# Altium schematic sheet 8-Controller.SchDoc(8-Controller)
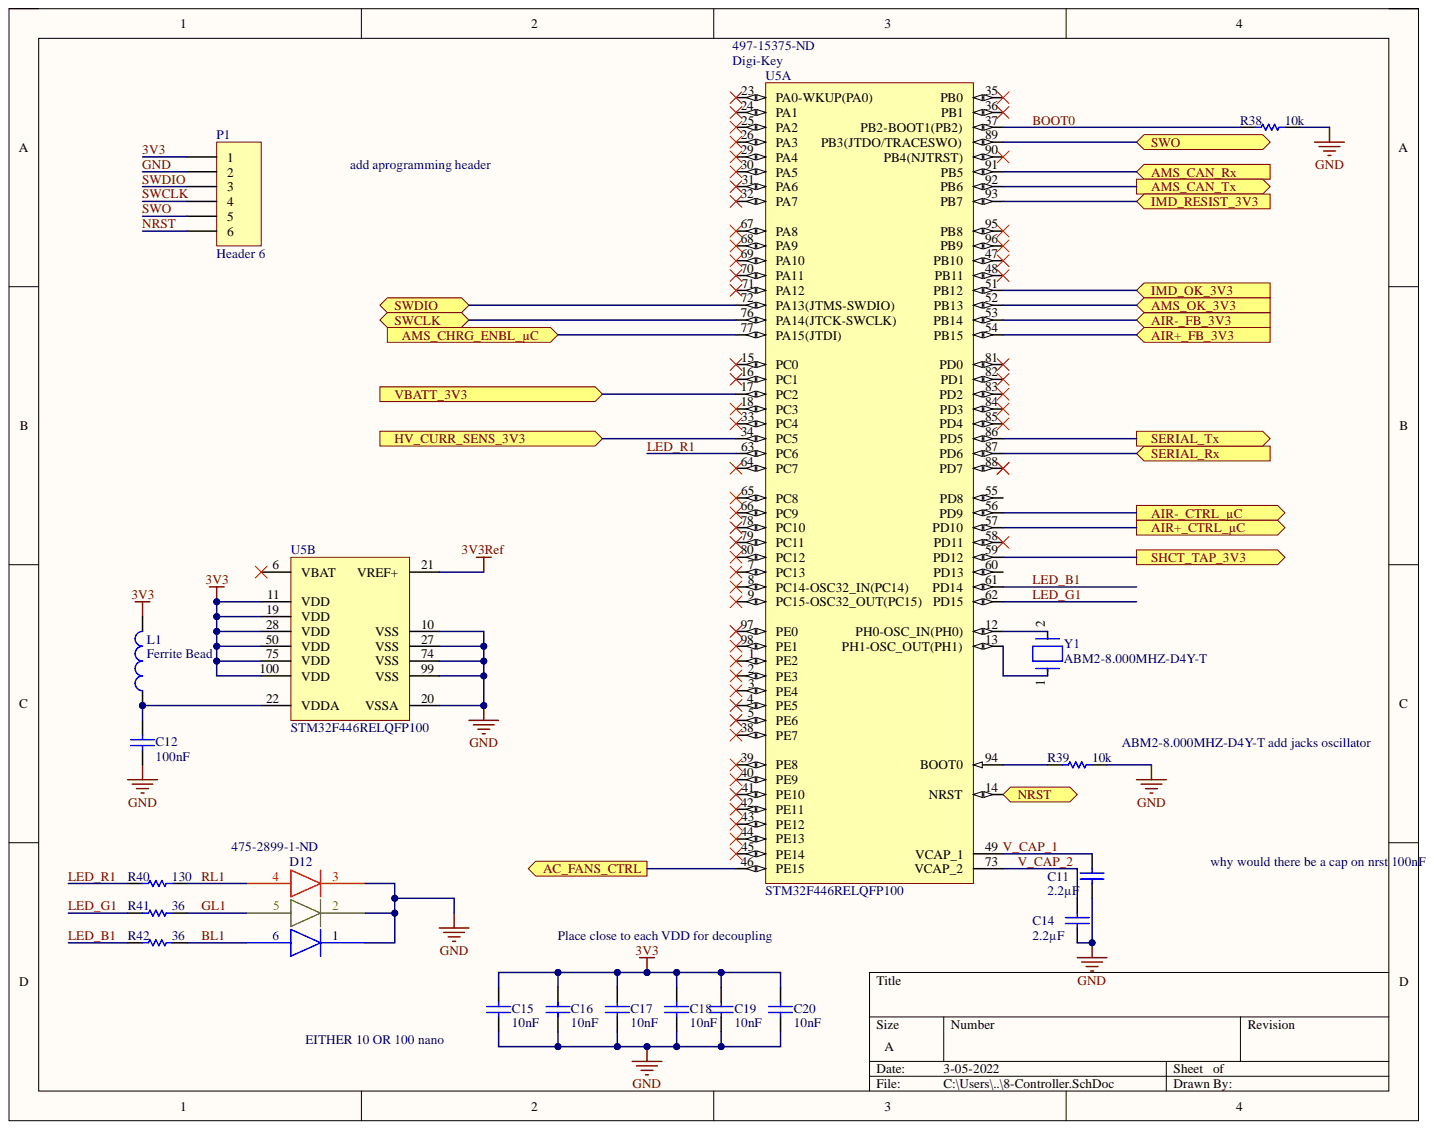
[diagram p0: 8-Controller.SchDoc]

## Parts
C11  Capacitance: 2.2µF  [1608[0603]]  (hidden comment: Cap)
C12  value 100nF  [1608[0603]]  (hidden comment: Cap)
C14  Capacitance: 2.2µF  [1608[0603]]  (hidden comment: Cap)
C15  value 10nF  [1608[0603]]  (hidden comment: Cap)
C16  value 10nF  [1608[0603]]  (hidden comment: Cap)
C17  value 10nF  [1608[0603]]  (hidden comment: Cap)
C18  value 10nF  [1608[0603]]  (hidden comment: Cap)
C19  value 10nF  [1608[0603]]  (hidden comment: Cap)
C20  value 10nF  [1608[0603]]  (hidden comment: Cap)
D12  Supplier Part Number 1: 475-2899-1-ND  [CLX6C-FKB]
L1  Ferrite Bead  [1608[0603]]
P1  Header 6  [HDR1X6]
R38  value 10k  Resistance (Ohms): 10k  [1608[0603]]  (hidden comment: Res1)
R39  value 10k  Resistance (Ohms): 10k  [1608[0603]]  (hidden comment: Res1)
R40  value 130  [1608[0603]]  (hidden comment: Res1)
R41  value 36  [1608[0603]]  (hidden comment: Res1)
R42  value 36  [1608[0603]]  (hidden comment: Res1)
U5  STM32F446RELQFP100  Supplier 1: Digi-Key  [STM-LQFP100_M]  (parts A,B)
Y1  ABM2-8.000MHZ-D4Y-T  [FP-ABM2-MFG]

## Ports
AC_FANS_CTRL, AIR+_CTRL_µC, AIR+_FB_3V3, AIR-_CTRL_µC, AIR-_FB_3V3, AMS_CAN_Rx, AMS_CAN_Tx, AMS_CHRG_ENBL_µC, AMS_OK_3V3, HV_CURR_SENS_3V3, IMD_OK_3V3, IMD_RESIST_3V3, NRST, SERIAL_Rx, SERIAL_Tx, SHCT_TAP_3V3, SWCLK, SWDIO, SWO, VBATT_3V3

## Nets
3V3Ref: U5.21(VREF+)
3V3: C15.2 C16.2 C17.2 C18.2 C19.2 C20.2 L1.2 P1.1 U5.11(VDD) U5.19(VDD) U5.28(VDD) U5.50(VDD) U5.75(VDD) U5.100(VDD)
AC_FANS_CTRL: U5.46(PE15) ; port AC_FANS_CTRL
AMS_CAN_Rx: U5.91(PB5) ; port AMS_CAN_Rx
AMS_CAN_Tx: U5.92(PB6) ; port AMS_CAN_Tx
AMS_CHRG_ENBL_µC: U5.77(PA15(JTDI)) ; port AMS_CHRG_ENBL_µC
AMS_OK_3V3: U5.52(PB13) ; port AMS_OK_3V3
BL1: D12.6 R42.2
BOOT0: R38.1 U5.37(PB2-BOOT1(PB2))
GL1: D12.5 R41.2
GND: C11.1 C12.1 C14.1 C15.1 C16.1 C17.1 C18.1 C19.1 C20.1 D12.1 D12.2 D12.3 P1.2 R38.2 R39.2 U5.10(VSS) U5.20(VSSA) U5.27(VSS) U5.74(VSS) U5.99(VSS)
HV_CURR_SENS_3V3: U5.34(PC5) ; port HV_CURR_SENS_3V3
IMD_OK_3V3: U5.51(PB12) ; port IMD_OK_3V3
IMD_RESIST_3V3: U5.93(PB7) ; port IMD_RESIST_3V3
LED_B1: R42.1 U5.61(PD14)
LED_G1: R41.1 U5.62(PD15)
LED_R1: R40.1 U5.63(PC6)
NRST: P1.6
RL1: D12.4 R40.2
SERIAL_Rx: U5.87(PD6) ; port SERIAL_Rx
SERIAL_Tx: U5.86(PD5) ; port SERIAL_Tx
SHCT_TAP_3V3: U5.59(PD12) ; port SHCT_TAP_3V3
SWCLK: P1.4
SWDIO: P1.3
SWO: P1.5
V_CAP_1: C11.2 U5.49(VCAP_1)
V_CAP_2: C14.2 U5.73(VCAP_2)
VBATT_3V3: U5.17(PC2) ; port VBATT_3V3
NetC12_2: C12.2 L1.1 U5.22(VDDA)
NetR39_1: R39.1 U5.94(BOOT0)
NetU5_12: U5.12(PH0-OSC_IN(PH0)) Y1.2
NetU5_13: U5.13(PH1-OSC_OUT(PH1)) Y1.1
NetU5_14: U5.14(NRST) ; port NRST
NetU5_53: U5.53(PB14)
NetU5_54: U5.54(PB15)
NetU5_56: U5.56(PD9)
NetU5_57: U5.57(PD10)
NetU5_72: U5.72(PA13(JTMS-SWDIO)) ; port SWDIO
NetU5_76: U5.76(PA14(JTCK-SWCLK)) ; port SWCLK
NetU5_89: U5.89(PB3(JTDO/TRACESWO)) ; port SWO

Not connected: U5 1(PE2) 2(PE3) 3(PE4) 4(PE5) 5(PE6) 6(VBAT) 7(PC13) 8(PC14-OSC32_IN(PC14)) 9(PC15-OSC32_OUT(PC15)) 15(PC0) 16(PC1) 18(PC3) 23(PA0-WKUP(PA0)) 24(PA1) 25(PA2) 26(PA3) 29(PA4) 30(PA5) 31(PA6) 32(PA7) 33(PC4) 35(PB0) 36(PB1) 38(PE7) 39(PE8) 40(PE9) 41(PE10) 42(PE11) 43(PE12) 44(PE13) 45(PE14) 47(PB10) 48(PB11) 55(PD8) 58(PD11) 60(PD13) 64(PC7) 65(PC8) 66(PC9) 67(PA8) 68(PA9) 69(PA10) 70(PA11) 71(PA12) 78(PC10) 79(PC11) 80(PC12) 81(PD0) 82(PD1) 83(PD2) 84(PD3) 85(PD4) 88(PD7) 90(PB4(NJTRST)) 95(PB8) 96(PB9) 97(PE0) 98(PE1)

## Library data of the parts
C11 C14 = Cap: Capacitor; Manufacturer: Taiyo Yuden; Manufacturer Part Number: TMK107BBJ225KAHT; Temperature Coefficient: X5R; Tolerance: ±10%; Voltage - Rated: 25V; Applications: General Purpose; Category: Capacitors; Component Kind: Standard; ComponentLink1Description: http://www.yuden.co.jp/productdata/catalog/en/mlcc_all_hq_e.pdf; ComponentLink1URL: http://www.yuden.co.jp/productdata/catalog/en/mlcc_all_hq_e.pdf; Features: -; Height - Seated (Max): -; Lead Spacing: -; Lead Style: -; Library Name: Miscellaneous Devices.IntLib; Mounting Type: Surface Mount, MLCC; Operating Temperature: -55°C ~ 85°C; Package / Case: 0603 (1608 Metric); Packaging: Cut Tape (CT); Part Status: Active; Pricing 1: 1=0.28, 10=0.193, 25=0.1416, 50=0.116, 100=0.0979, 250=0.07992, 500=0.06702, 1000=0.05928 (CAD); Ratings: AEC-Q200; RoHS: RoHS Compliant; Signal Integrity: Cap; Simulation: CAP; Size / Dimension: 0.063" L x 0.031" W (1.60mm x 0.80mm); Stock 1: 6587; Supplier 1: Digi-Key; Supplier Part Number 1: 587-4333-1-ND; Thickness (Max): 0.035" (0.90mm)
C12 = Cap: Capacitor; Manufacturer: Yageo; Manufacturer Part Number: CC0603KRX7R9BB104; Capacitance: 0.10µF; Temperature Coefficient: X7R; Tolerance: ±10%; Voltage - Rated: 50V; Applications: General Purpose; Category: Capacitors; Component Kind: Standard; ComponentLink1Description: http://www.yageo.com/documents/recent/UPY-GPHC_X7R_6.3V-to-50V_16.pdf; ComponentLink1URL: http://www.yageo.com/documents/recent/UPY-GPHC_X7R_6.3V-to-50V_16.pdf; Failure Rate: -; Features: -; Height - Seated (Max): -; Lead Spacing: -; Lead Style: -; Library Name: Miscellaneous Devices.IntLib; Mounting Type: Surface Mount, MLCC; Operating Temperature: -55°C ~ 125°C; Package / Case: 0603 (1608 Metric); Packaging: Cut Tape (CT); Part Status: Active; Pricing 1: 1=0.15, 10=0.043, 25=0.0312, 50=0.0232, 100=0.0189, 250=0.0158, 500=0.01346, 1000=0.01059 (CAD); Ratings: -; RoHS: RoHS Compliant; Signal Integrity: Cap; Simulation: CAP; Size / Dimension: 0.063" L x 0.031" W (1.60mm x 0.80mm); Stock 1: 4016262; Supplier 1: Digi-Key; Supplier Part Number 1: 311-1344-1-ND; Thickness (Max): 0.035" (0.90mm)
C15-C20 = Cap: Capacitor; Manufacturer: Yageo; Manufacturer Part Number: CC0603KRX7R9BB103; Capacitance: 10000pF; Temperature Coefficient: X7R; Tolerance: ±10%; Voltage - Rated: 50V; Applications: General Purpose; Category: Capacitors; Component Kind: Standard; ComponentLink1Description: http://www.yageo.com/documents/recent/UPY-GPHC_X7R_6.3V-to-50V_16.pdf; ComponentLink1URL: http://www.yageo.com/documents/recent/UPY-GPHC_X7R_6.3V-to-50V_16.pdf; Failure Rate: -; Features: -; Height - Seated (Max): -; Lead Spacing: -; Lead Style: -; Library Name: Miscellaneous Devices.IntLib; Mounting Type: Surface Mount, MLCC; Operating Temperature: -55°C ~ 125°C; Package / Case: 0603 (1608 Metric); Packaging: Cut Tape (CT); Part Status: Active; Pricing 1: 1=0.15, 10=0.026, 25=0.0192, 50=0.0144, 100=0.0117, 250=0.0098, 500=0.00836, 1000=0.00657 (CAD); Ratings: -; RoHS: RoHS Compliant; Signal Integrity: Cap; Simulation: CAP; Size / Dimension: 0.063" L x 0.031" W (1.60mm x 0.80mm); Stock 1: 3577285; Supplier 1: Digi-Key; Supplier Part Number 1: 311-1085-1-ND; Thickness (Max): 0.035" (0.90mm)
D12 = LED - CLX6C-FKB-CGJKNCFBB789363 RGB: Manufacturer: Cree Inc.; Color: Red, Green, Blue (RGB); Current - Test: 8mA Red, 8mA Green, 8mA Blue; Lens Color: White; Lens Style/Size: Square with Flat Top, 1.00mm; Lens Transparency: Diffused; Millicandela Rating: 420mcd Red, 840mcd Green, 196mcd Blue; Voltage - Forward (Vf) (Typ): 1.9V Red, 3V Green, 3V Blue; Wavelength - Dominant: 622nm Red, 531nm Green, 470nm Blue; Category: Optoelectronics; Component Kind: Standard; ComponentLink1Description: http://www.cree.com/~/media/Files/Cree/LED-Components-and-Modules/HB/Data-Sheets/1281-CLX6C-FKB.pdf; ComponentLink1URL: http://www.cree.com/~/media/Files/Cree/LED-Components-and-Modules/HB/Data-Sheets/1281-CLX6C-FKB.pdf; Features: -; Height (Max): 2.90mm; Manufacturer Part Number: CLX6C-FKB-CGJKNCFBB789363; Mounting Type: Surface Mount; Package / Case: 6-SMD, J-Lead; Packaging: Cut Tape (CT); Part Status: Active; RoHS: RoHS Compliant; Size / Dimension: 3.40mm L x 3.40mm W; Supplier 1: Digi-Key; Supplier Device Package: 6-PLCC; Viewing Angle: -; Wavelength - Peak: -; Library Name: VCU Library.SchLib
L1 = Inductor: Code_IEC: 1005; Code_JEITA: 0402; Component Kind: Standard; Description: Inductor; LatestRevisionDate: 29-May-2009; LatestRevisionNote: IPC-7351 Footprint Added.; Library Name: Miscellaneous Devices.IntLib; PackageDescription: Chip Inductor; PackageReference: 0402-A; Published: 8-Jun-2000; Signal Integrity: Inductor; Simulation: INDUCTOR; Supplier 1: Digi-Key; Supplier Part Number 1: 240-2369-1-ND; Publisher: Altium Limited
P1 = Header 6: Header, 6-Pin; Component Kind: Standard; LatestRevisionDate: 17-Jul-2002; LatestRevisionNote: Re-released for DXP Platform.; Library Name: Miscellaneous Connectors.IntLib; Signal Integrity: Connector; Publisher: Altium Limited
R38 R39 = Res1: Resistor; Manufacturer: Yageo; Manufacturer Part Number: RC0603JR-0710KL; Power (Watts): 0.1W, 1/10W; Temperature Coefficient: ±100ppm/°C; Tolerance: ±5%; Category: Resistors; Component Kind: Standard; ComponentLink1Description: http://www.yageo.com/NewPortal/yageodocoutput?fileName=/pdf/R-Chip/PYu-RC_Group_51_RoHS_L_4.pdf; ComponentLink1URL: http://www.yageo.com/NewPortal/yageodocoutput?fileName=/pdf/R-Chip/PYu-RC_Group_51_RoHS_L_4.pdf; Composition: Thick Film; Failure Rate: -; Features: Moisture Resistant; Height - Seated (Max): 0.022" (0.55mm); Library Name: Miscellaneous Devices.IntLib; Number of Terminations: 2; Operating Temperature: -55°C ~ 155°C; Package / Case: 0603 (1608 Metric); Packaging: Cut Tape (CT); Part Status: Active; Pricing 1: 1=0.16, 10=0.016, 25=0.0112, 50=0.0084, 100=0.0062, 250=0.0048, 500=0.00382, 1000=0.00281 (CAD); RoHS: RoHS Compliant; Signal Integrity: Res; Simulation: RESISTOR; Size / Dimension: 0.063" L x 0.031" W (1.60mm x 0.80mm); Stock 1: 2936292; Supplier 1: Digi-Key; Supplier Device Package: 0603; Supplier Part Number 1: 311-10KGRCT-ND
R40 = Res1: Resistor; Manufacturer: Yageo; Manufacturer Part Number: RC0603JR-07130RL; Power (Watts): 0.1W, 1/10W; Temperature Coefficient: ±100ppm/°C; Tolerance: ±5%; Category: Resistors; Component Kind: Standard; ComponentLink1Description: http://www.yageo.com/NewPortal/yageodocoutput?fileName=/pdf/R-Chip/PYu-RC_Group_51_RoHS_L_4.pdf; ComponentLink1URL: http://www.yageo.com/NewPortal/yageodocoutput?fileName=/pdf/R-Chip/PYu-RC_Group_51_RoHS_L_4.pdf; Composition: Thick Film; Failure Rate: -; Features: Moisture Resistant; Height - Seated (Max): 0.022" (0.55mm); Library Name: Miscellaneous Devices.IntLib; Number of Terminations: 2; Operating Temperature: -55°C ~ 155°C; Package / Case: 0603 (1608 Metric); Packaging: Cut Tape (CT); Part Status: Active; Pricing 1: 1=0.16, 10=0.016, 25=0.0112, 50=0.0084, 100=0.0062, 250=0.0048, 500=0.00382, 1000=0.00281 (CAD); Resistance (Ohms): 130; RoHS: RoHS Compliant; Signal Integrity: Res; Simulation: RESISTOR; Size / Dimension: 0.063" L x 0.031" W (1.60mm x 0.80mm); Stock 1: 74185; Supplier 1: Digi-Key; Supplier Device Package: 0603; Supplier Part Number 1: 311-130GRCT-ND
R41 R42 = Res1: Resistor; Manufacturer: Yageo; Manufacturer Part Number: RC0603JR-0736RL; Power (Watts): 0.1W, 1/10W; Temperature Coefficient: ±100ppm/°C; Tolerance: ±5%; Category: Resistors; Component Kind: Standard; ComponentLink1Description: http://www.yageo.com/NewPortal/yageodocoutput?fileName=/pdf/R-Chip/PYu-RC_Group_51_RoHS_L_4.pdf; ComponentLink1URL: http://www.yageo.com/NewPortal/yageodocoutput?fileName=/pdf/R-Chip/PYu-RC_Group_51_RoHS_L_4.pdf; Composition: Thick Film; Failure Rate: -; Features: Moisture Resistant; Height - Seated (Max): 0.022" (0.55mm); Library Name: Miscellaneous Devices.IntLib; Number of Terminations: 2; Operating Temperature: -55°C ~ 155°C; Package / Case: 0603 (1608 Metric); Packaging: Cut Tape (CT); Part Status: Active; Pricing 1: 1=0.16, 10=0.016, 25=0.0112, 50=0.0084, 100=0.0062, 250=0.0048, 500=0.00382, 1000=0.00281 (CAD); Resistance (Ohms): 36; RoHS: RoHS Compliant; Signal Integrity: Res; Simulation: RESISTOR; Size / Dimension: 0.063" L x 0.031" W (1.60mm x 0.80mm); Stock 1: 43300; Supplier 1: Digi-Key; Supplier Device Package: 0603; Supplier Part Number 1: 311-36GRCT-ND
U5 = CMP-0243-00042-2: ARM Cortex-M4 32-bit MCU+FPU, 225 DMIPS, 2048 kB Flash, 256 kB Internal RAM, 81 I/Os, 100-pin LQFP, -40 to 85 degC, Tray; Manufacturer: STMicroelectronics; Manufacturer Part Number: STM32F446VET6; PartNumber: STM32F439VIT6; Connectivity: CAN, EBI/EMI, I²C, IrDA, LIN, SAI, SD, SPDIF-Rx, SPI, UART/USART, USB, USB OTG; Core Processor: ARM® Cortex®-M4; D/A Converter: 2x12-bit; Data Converters: A/D 16x12b, D/A 2x12b; FLASH Size (Prog) (kB): 2048; I/Os (High Current): 82; Internal RAM Size (kB): 256; Number of I/O: 81; Operating Frequency(F) (Processor speed) (MHz): 180; Peripherals: Brown-out Detect/Reset, DMA, I²S, LVD, POR, PWM, WDT; Program Memory Size: 512KB (512K x 8); RAM Size: 128K x 8; Rule: Clearance Constraint [Generic clearance = 10mil, and 9 value(s) for objects]; Speed: 180MHz; Supply Voltage(Vcc) max (V): 3.6; Supply Voltage(Vcc) min (V): 1.8; Voltage - Supply (Vcc/Vdd): 1.7 V ~ 3.6 V; Category: Integrated Circuits (ICs); Component Kind: Standard; ComponentLink5Description: ACTUAL DATASHEET; ComponentLink5URL: https://www.st.com/content/ccc/resource/technical/document/datasheet/65/cb/75/50/53/d6/48/24/DM00141306.pdf/files/DM00141306.pdf/jcr:content/translations/en.DM00141306.pdf; Core: ARM Cortex-M4; Core Size: 32-Bit; DatasheetVersion: Rev. 2; EEPROM Size: -; Library Name: Altium Content Vault; Mounting Technology: Surface Mount; Operating Temperature: -40°C ~ 85°C (TA); Oscillator Type: Internal; Package / Case: 100-LQFP; PackageDescription: 100-Pin Low Profile Quad Flat Package, 14 x 14 mm Body, 0.5 mm Pitch; PackageReference: LQFP100; PackageVersion: Rev. 1, 06/2013; Packaging: Tray; Packing: Tray; Part Status: Active; PartId: 1; Pricing 1: 1=14.71, 10=13.292, 100=11.0054, 500=9.58332, 1000=8.34676 (CAD); Program Memory Type: FLASH; RoHS: RoHS Compliant; Stock 1: 560; Supplier Device Package: 100-LQFP (14x14); Supplier Part Number 1: 497-15375-ND
Y1 = CMP-27762-013641-1: Crystal 8MHz ±30ppm 18pF SMD-2 8mm x 4.5mm; ESR (Equivalent Series Resistance): 100R; Case/Package: CSMD; Component Kind: Standard; Depth: 4.5mm; Frequency: 8MHz; Frequency Stability: 30ppm; Frequency Tolerance: 30ppm; Height: 0.063inch; Lead Free: Lead Free; Length: 8mm; Library Name: Altium Content Vault; Load Capacitance: 18pF; Max Operating Temperature: 85°C; Min Operating Temperature: -40°C; Mount: Surface Mount; Number of Pins: 2; Operating Frequency: 8 MHz; Package Quantity: 1000; Packaging: Tape and Reel; Radiation Hardening: No; Resistor Type: Ceramic; Series: ABM2; Termination: SMD/SMT; Tolerance: 30ppm; Width: 4.5mm
